# Altium schematic sheet RF FRONTEND.SchDoc
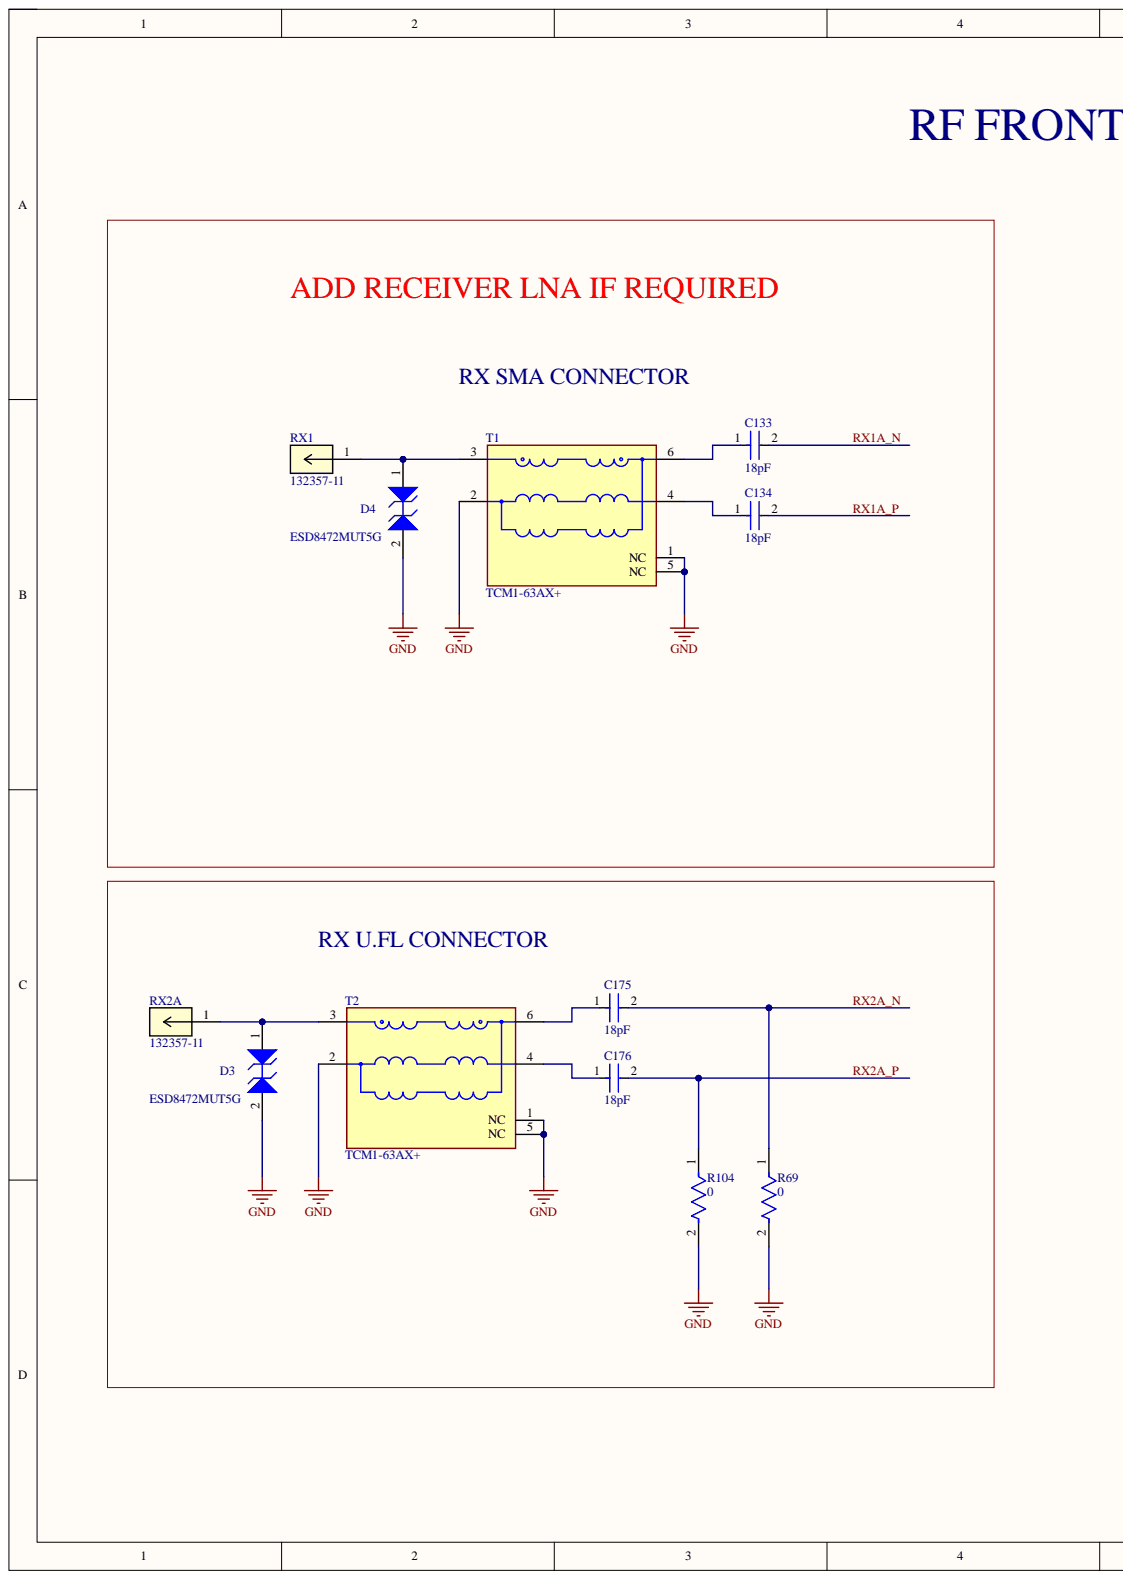
[diagram p0: RF FRONTEND.SchDoc, left]
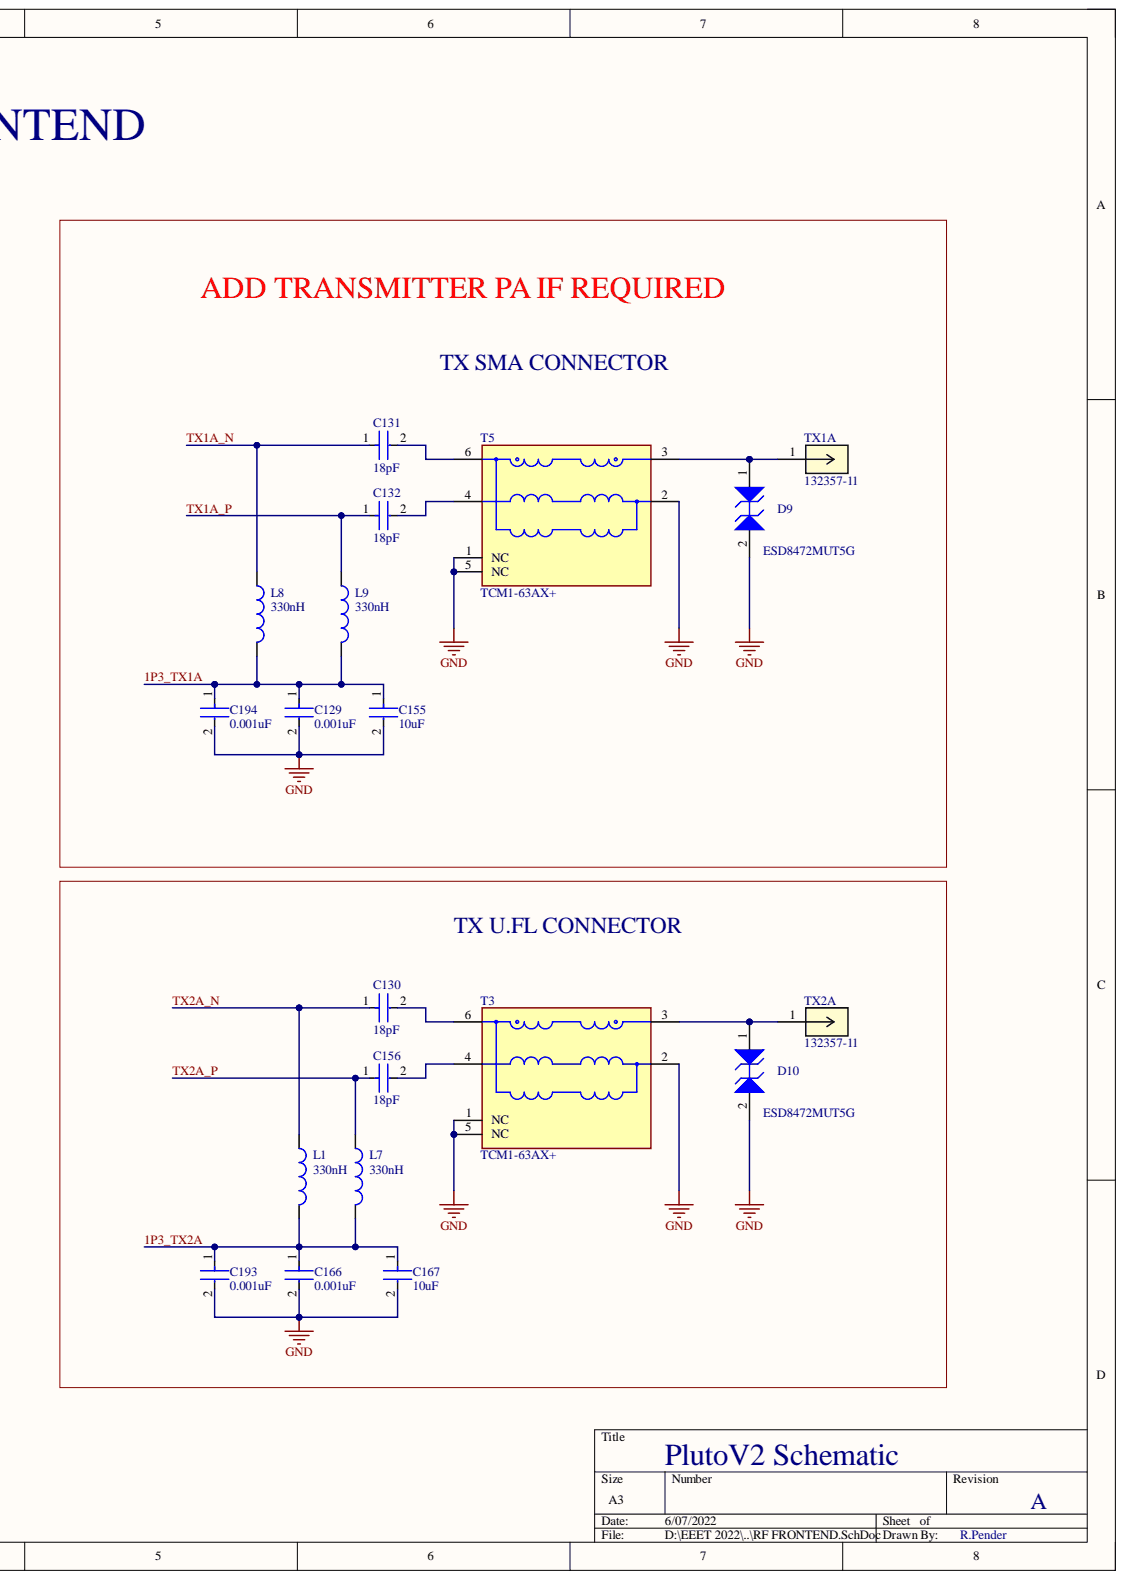
[diagram p1: RF FRONTEND.SchDoc, right]

## Parts
C129  0.001uF  [FP-GRM033-0_03-MFG]
C130  18pF  [FP-GRM033-0_03-IPC_A]
C131  18pF  [FP-GRM033-0_03-IPC_A]
C132  18pF  [FP-GRM033-0_03-IPC_A]
C133  18pF  [FP-GRM033-0_03-IPC_A]
C134  18pF  [FP-GRM033-0_03-IPC_A]
C155  10uF  [FP-GRM188-0_1-e0_2_0_55-IPC_C]
C156  18pF  [FP-GRM033-0_03-IPC_A]
C166  0.001uF  [FP-GRM033-0_03-MFG]
C167  10uF  [FP-GRM188-0_1-e0_2_0_55-IPC_C]
C175  18pF  [FP-GRM033-0_03-IPC_A]
C176  18pF  [FP-GRM033-0_03-IPC_A]
C193  0.001uF  [FP-GRM033-0_03-MFG]
C194  0.001uF  [FP-GRM033-0_03-MFG]
D3  ESD8472MUT5G  [ONSC-X3DFN-2-152AF-01_V]
D4  ESD8472MUT5G  [ONSC-X3DFN-2-152AF-01_V]
D9  ESD8472MUT5G  [ONSC-X3DFN-2-152AF-01_V]
D10  ESD8472MUT5G  [ONSC-X3DFN-2-152AF-01_V]
L1  330nH  [INDC2317X140N]
L7  330nH  [INDC2317X140N]
L8  330nH  [INDC2317X140N]
L9  330nH  [INDC2317X140N]
R69  0  [FP-ERJ1GN-MFG]
R104  0  [FP-ERJ1GN-MFG]
RX1  132357-11  [CONN_132357-11_AMP]
RX2A  132357-11  [CONN_132357-11_AMP]
T1  TCM1-63AX+  [FP-TCM1-63AX+-MFG]
T2  TCM1-63AX+  [FP-TCM1-63AX+-MFG]
T3  TCM1-63AX+  [FP-TCM1-63AX+-MFG]
T5  TCM1-63AX+  [FP-TCM1-63AX+-MFG]
TX1A  132357-11  [CONN_132357-11_AMP]
TX2A  132357-11  [CONN_132357-11_AMP]

## Nets
GND: C129.2 C155.2 C166.2 C167.2 C193.2 C194.2 D3.2 D4.2 D9.2 D10.2 R69.2 R104.2 T1.1(NC) T1.2 T1.5(NC) T2.1(NC) T2.2 T2.5(NC) T3.1(NC) T3.2 T3.5(NC) T5.1(NC) T5.2 T5.5(NC)
1P3_TX1A: C129.1 C155.1 C194.1 L8.2 L9.2
1P3_TX2A: C166.1 C167.1 C193.1 L1.2 L7.2
RX1A_N: C133.2
RX1A_P: C134.2
RX2A_N: C175.2 R69.1
RX2A_P: C176.2 R104.1
TX1A_N: C131.1 L8.1
TX1A_P: C132.1 L9.1
TX2A_N: C130.1 L1.1
TX2A_P: C156.1 L7.1
NetC130_2: C130.2 T3.6
NetC131_2: C131.2 T5.6
NetC132_2: C132.2 T5.4
NetC133_1: C133.1 T1.6
NetC134_1: C134.1 T1.4
NetC156_2: C156.2 T3.4
NetC175_1: C175.1 T2.6
NetC176_1: C176.1 T2.4
NetD3_1: D3.1 RX2A.1 T2.3
NetD4_1: D4.1 RX1.1 T1.3
NetD9_1: D9.1 T5.3 TX1A.1
NetD10_1: D10.1 T3.3 TX2A.1

## Library data of the parts
C129 C166 C193 C194 = CMP-2010-01706-2: Chip Multilayer Ceramic Capacitors for General Purpose, 0201, 1000pF, X7R, 15%, 10%, 25V; Capacitance: 1nF; Case Code (Imperial): 0201; Case Code (Metric): 0603; Case/Package: 0201; Component Kind: Standard; Depth: 0.3mm; Dielectric: X7R; Height: 0.3mm; Lead Free: Lead Free; Length: 0.6mm; Library Name: Altium Content Vault; Material: Ceramic; Max Operating Temperature: 125°C; Min Operating Temperature: -55°C; Mount: Surface Mount; Package Quantity: 1; Packaging: Tape and Reel; Radiation Hardening: No; REACH SVHC: No SVHC; Termination: SMD/SMT; Thickness: 0.013inch; Tolerance: 10%; Voltage: 25V; Voltage Rating: 25V; Voltage Rating (DC): 25V; Width: 0.012inch
C130-C134 C156 C175 C176 = CMP-2010-01507-2: Chip Multilayer Ceramic Capacitors for General Purpose, 0201, 18pF, C0G, 30ppm/°C, 5%, 50V; Capacitance: 18pF; Case Code (Imperial): 0201; Case Code (Metric): 0603; Case/Package: 0201; Component Kind: Standard; Depth: 0.3mm; Dielectric: C0G; Height: 0.3mm; Lead Free: Lead Free; Length: 0.6mm; Library Name: Altium Content Vault; Max Operating Temperature: 125°C; Min Operating Temperature: -55°C; Mount: Surface Mount; Package Quantity: 15000; Packaging: Tape and Reel; Radiation Hardening: No; Termination: SMD/SMT; Thickness: 0.013inch; Tolerance: 5%; Voltage: 50V; Voltage Rating: 50V; Voltage Rating (DC): 50V; Width: 0.3mm
C155 C167 = CMP-2006-02792-2: Chip Multilayer Ceramic Capacitors for General Purpose, 0603, 10uF, X5R, 15%, 20%, 6.3V; Capacitance: 10uF; Case Code (Imperial): 0603; Case Code (Metric): 1608; Case/Package: 0603; Component Kind: Standard; Depth: 0.8mm; Dielectric: X5R; Height: 0.8mm; Lead Free: Lead Free; Length: 1.6mm; Library Name: Altium Content Vault; Material: Ceramic; Max Operating Temperature: 85°C; Min Operating Temperature: -55°C; Mount: Surface Mount; Package Quantity: 4000; Packaging: Tape and Reel; Radiation Hardening: No; REACH SVHC: No SVHC; Termination: SMD/SMT; Thickness: 0.035inch; Tolerance: 20%; Voltage: 6.3V; Voltage Rating: 6.3V; Voltage Rating (DC): 6.3V; Width: 0.031inch
D3 D4 D9 D10 = CMP-1063-00281-1: Ultra-Low Capacitance ESD Protection, Micro-Packaged Diode for ESD Protection, 2-Pin X3DFN, Pb-Free, Tape and Reel; Manufacturer: On Semiconductor; C Max (pF): 0.3; Direction: Bidirectional; IR Max (uA): 0.05; Number of Lines: 1; V(BR) Min (V): 7; VRWM Max (V): 5.3; Component Kind: Standard; ComponentLink1Description: Manufacturer URL; ComponentLink1URL: http://www.onsemi.com/; ComponentLink2Description: Datasheet; ComponentLink2URL: http://www.onsemi.cn/pub_link/Collateral/ESD8472-D.PDF; ComponentLink3Description: Package Specification; ComponentLink3URL: http://www.onsemi.cn/pub_link/Collateral/152AF.PDF; DatasheetVersion: Rev. 4; Library Name: Altium Content Vault; Mounting Technology: Surface Mount; PackageDescription: 2-Pin Small Outline No-Lead, Body 0.62 x 0.32 mm, Pitch 0.355 mm; PackageReference: X3DFN-2-152AF-01; PackageVersion: Rev. O, 04/2011; Packing: Tape and Reel; PartNumber: ESD8472MUT5G; RoHS: Pb-Free
L1 L7-L9 = Inductor: Code_IEC: 1005; Value: 10mH; Code_JEITA: 0402; Component Kind: Standard; Description: Inductor; LatestRevisionDate: 29-May-2009; LatestRevisionNote: IPC-7351 Footprint Added.; Library Name: Miscellaneous Devices.IntLib; PackageDescription: Chip Inductor; PackageReference: 0402-A; Published: 8-Jun-2000; Signal Integrity: Inductor; Simulation: INDUCTOR; Publisher: Altium Limited
R69 R104 = CMP-1010-00001-3: RES SMD 0 OHM JUMPER 1/20W 0201; Max Power Dissipation: 50mW; Resistance: 0R; Temperature Coefficient: 600ppm/°C; Tolerance: 1%; Voltage Rating: 25V; Case Code (Imperial): 0201; Case Code (Metric): 0603; Case/Package: 0201; Component Kind: Standard; Construction: Rectangular; Contact Plating: Tin; Depth: 0.3mm; Features: Automotive AEC-Q200; Height: 0.23mm; Lead Free: Lead Free; Length: 0.6mm; Library Name: Altium Content Vault; Max Operating Temperature: 125°C; Military Standard: Not; Min Operating Temperature: -55°C; Mount: Surface Mount; Number of Terminations: 2; Package Quantity: 15000; Packaging: Tape and Reel; Power Rating: 50mW; Resistor Type: Thick Film; RoHS Compliant: Yes; Series: ERJ; Voltage Rating (DC): 25V; Width: 0.3mm
RX1 RX2A TX1A TX2A = 132357-11: No Description Available; Mfr_Name: Amphenol ICC; Component Kind: Standard; Digi-Key_Part_Number_1: ACX1911-ND; Library Name: 132357-11.SchLib; Manufacturer_Part_Number: 132357-11; RefDes: RefDes; Type: DEV; Copyright: Copyright (C) 2022 Ultra Librarian. All rights reserved.
T1-T3 T5 = CMP-199822-000001-1: 1:1 CORE & WIRE Transformer, 10 - 6000 MHz, 50Ω; Manufacturer: Mini-Circuits; Insertion Loss: 1.16-1.8dB; Return Loss: 9.42-16.9dB; Automotive: No; Category: Trans; Component Kind: Standard; ComponentLink2Description: Datasheet; ComponentLink2URL: https://www.minicircuits.com/pdfs/TCM1-63AX+.pdf; Device Class L1: Passive Components; Device Class L2: Transformers; Device Class L3: unset; Frequency: 10-6000MHz; Height: 4.06mm; Lead Free: Yes; Library Name: Altium Content Vault; Manufacturer Part Number: TCM1-63AX+; Octopart: https://octopart.com/tcm1-63ax%2B-mini-circuits-107315723; Package: 0; RoHS: TRUE; Temperature: -40°C to +85°C; Temperature Range High: +85°C; Temperature Range Low: -40°C; Turns Ratio: 1:1
